# Altium schematic sheet Signal_Generator.SchDoc(Signal_Generator)
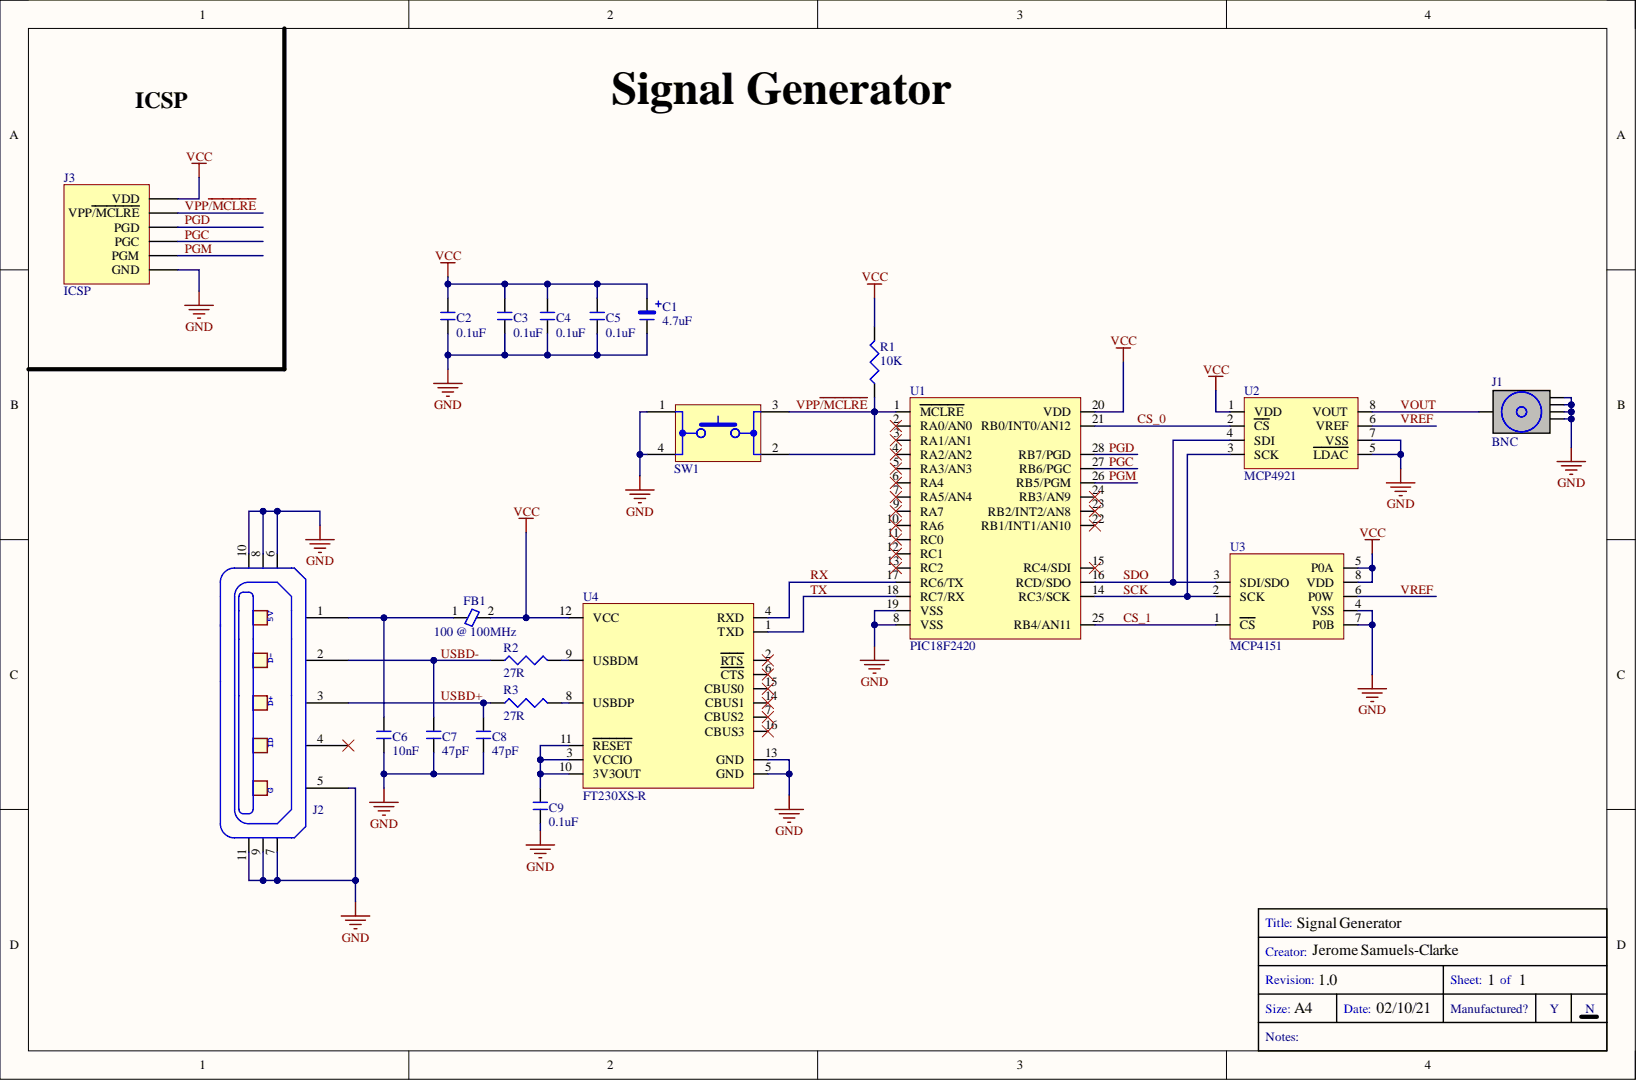
[diagram p0: Signal_Generator.SchDoc]

## Parts
C1  4.7uF  [CAP ALUM 4.7UF]
C2  0.1uF  [C_0603]
C3  0.1uF  [C_0603]
C4  0.1uF  [C_0603]
C5  0.1uF  [C_0603]
C6  10nF  [C_0603]
C7  47pF  [C_0603]
C8  47pF  [C_0603]
C9  0.1uF  [C_0603]
FB1  100 @ 100MHz  [FB_0603]
J1  BNC  [BNC]
J2  10118193-0001LF  [Micro_USB]  (value not drawn)
J3  ICSP  [ICSP]
R1  10K  [R_0603]
R2  27R  [R_0603]
R3  27R  [R_0603]
SW1  SPST  [Right_Angled_Push_Button]  (value not drawn)
U1  PIC18F2420  [PIC18F2420_SOIC]
U2  MCP4921  [MCP4921_MSOP]
U3  MCP4151  [MCP4151_MSOP]
U4  FT230XS-R  [FT230X_SOIC]

## Nets
GND: C1.2 C2.1 C3.1 C4.1 C5.1 C6.1 C7.1 C8.1 C9.1 J1.1 J1.2 J1.3 J1.4 J2.5 J2.6 J2.7 J2.8 J2.9 J2.10 J2.11 J3.3(GND) SW1.1 SW1.4 U1.8(VSS) U1.19(VSS) U2.5(LDAC) U2.7(VSS) U3.4(VSS) U3.7(P0B) U4.5(GND) U4.13(GND)
VCC: C1.1 C2.2 C3.2 C4.2 C5.2 FB1.2 J3.2(VDD) R1.2 U1.20(VDD) U2.1(VDD) U3.5(P0A) U3.8(VDD) U4.12(VCC)
CS_0: U1.21(RB0/INT0/AN12) U2.2(CS)
CS_1: U1.25(RB4/AN11) U3.1(CS)
PGC: J3.5(PGC) U1.27(RB6/PGC)
PGD: J3.4(PGD) U1.28(RB7/PGD)
PGM: J3.6(PGM) U1.26(RB5/PGM)
RX: U1.17(RC6/TX) U4.4(RXD)
SCK: U1.14(RC3/SCK) U2.3(SCK) U3.2(SCK)
SDO: U1.16(RCD/SDO) U2.4(SDI) U3.3(SDI/SDO)
TX: U1.18(RC7/RX) U4.1(TXD)
USBD+: C8.2 J2.3 R3.1
USBD-: C7.2 J2.2 R2.1
VOUT: J1.5 U2.8(VOUT)
VPP~{/MCLRE}: J3.1(VPP/MCLRE) R1.1 SW1.2 SW1.3 U1.1(MCLRE)
VREF: U2.6(VREF) U3.6(P0W)
NetC6_2: C6.2 FB1.1 J2.1
NetC9_2: C9.2 U4.3(VCCIO) U4.10(3V3OUT) U4.11(RESET)
NetR2_2: R2.2 U4.9(USBDM)
NetR3_2: R3.2 U4.8(USBDP)

Not connected: J2 4; U1 2(RA0/AN0) 3(RA1/AN1) 4(RA2/AN2) 5(RA3/AN3) 6(RA4) 7(RA5/AN4) 9(RA7) 10(RA6) 11(RC0) 12(RC1) 13(RC2) 15(RC4/SDI) 22(RB1/INT1/AN10) 23(RB2/INT2/AN8) 24(RB3/AN9); U4 2(RTS) 6(CTS) 7(CBUS2) 14(CBUS1) 15(CBUS0) 16(CBUS3)

## Library data of the parts
C1 = EEE-HA1E4R7AR: Cap Aluminum Lytic 4.7uF 25V 20% (4 X 5.4mm) SMD 22mA 1000h 105C T/R; Manufacturer: Panasonic; Life (Hours): 1000Hour; Polarity: Polar; Capacitance: 4.7uF; Case/Package: Radial; Category: Aluminum Electrolytic Capacitors; Component Kind: Standard; ComponentLink1Description: https://datasheet.ciiva.com/11681/aba0000ce3-11681160.pdf?src-supplier=Avnet; ComponentLink1URL: https://datasheet.ciiva.com/11681/aba0000ce3-11681160.pdf?src-supplier=Avnet; ComponentLink2Description: https://datasheet.ciiva.com/30252/aba0000ce3-30252438.pdf?src-supplier=Onlinecomponents.com; ComponentLink2URL: https://datasheet.ciiva.com/30252/aba0000ce3-30252438.pdf?src-supplier=Onlinecomponents.com; Construction: Cylindrical; Contact Plating: Tin; Diameter: 4mm; Height: 5.4mm; Height - Seated (Max): 0.213inch; Length: 5.5mm; Library Name: Signal_Generator.SchLib; Manufacturer Part Number: EEE-HA1E4R7AR; Max Operating Temperature: 105°C; Min Operating Temperature: -40°C; Mount: Surface Mount; Package Quantity: 1; Packaging: Tape and Reel; Pricing 1: 1=0.35000, 10=0.24400, 50=0.17100, 100=0.14660, 500=0.10996, 1000=0.09286 (USD); Radiation Hardening: No; Ripple Current: 22mA; RoHS: Yes; RoHS Compliant: Yes; Series: HA; Stock 1: 2093; Supplier 1: Digi-Key; Supplier Part Number 1: PCE4667CT-ND; Termination: SMD/SMT; Tolerance: 20%; Voltage Rating: 25V; Voltage Rating (DC): 25V
C2-C5 C9 = CC0603JRX7R7BB104: Cap Ceramic 0.1uF 16V X7R 5% SMD 0603 125C T/R; Manufacturer: Yageo; Capacitance: 100nF; Case Code (Imperial): 0603; Case Code (Metric): 1608; Case/Package: 0603; Category: Ceramic Capacitors; Component Kind: Standard; ComponentLink1Description: https://datasheet.ciiva.com/26862/getdatasheetpartid-403543-26862779.pdf?src-supplier=Verical; ComponentLink1URL: https://datasheet.ciiva.com/26862/getdatasheetpartid-403543-26862779.pdf?src-supplier=Verical; Depth: 0.8mm; Dielectric: X7R; Halogen Free: Halogen Free; Lead Free: Lead Free; Length: 1.6mm; Library Name: Signal_Generator.SchLib; Manufacturer Part Number: CC0603JRX7R7BB104; Max Operating Temperature: 125°C; Min Operating Temperature: -55°C; Mount: Surface Mount; Number of Pins: 2; Packaging: Tape and Reel; Pricing 1: 1=0.10000, 10=0.07700, 50=0.04200, 100=0.03430, 500=0.02448, 1000=0.01923 (USD); Radiation Hardening: No; RoHS: Yes; RoHS Compliant: Yes; Series: CC; Stock 1: 286099; Supplier 1: Digi-Key; Supplier Part Number 1: 311-1776-1-ND; Thickness: 0.035inch; Tolerance: 5%; Voltage Rating: 16V; Voltage Rating (DC): 16V; Width: 0.031inch
C6 = CC0603JRX7R8BB103: Surface Mount Ceramic Multilayer Capacitor 0.01uF 5% X7R 25V 0603 Paper T/R; Manufacturer: Yageo; Dissipation Factor: 2.5%; Insulation Resistance: 10GR; Capacitance: 10nF; Case Code (Imperial): 0603; Case Code (Metric): 1608; Case/Package: 0603; Category: Ceramic Capacitors; Component Kind: Standard; ComponentLink1Description: https://datasheet.ciiva.com/11465/upy-gphc-x7r-6-3v-to-50v-8-11465030.pdf?src-supplier=Avnet; ComponentLink1URL: https://datasheet.ciiva.com/11465/upy-gphc-x7r-6-3v-to-50v-8-11465030.pdf?src-supplier=Avnet; ComponentLink2Description: https://datasheet.ciiva.com/17437/upy-gphc-x7r-6-3v-to-50v-8-17437066.pdf?src-supplier=Avnet+Europe; ComponentLink2URL: https://datasheet.ciiva.com/17437/upy-gphc-x7r-6-3v-to-50v-8-17437066.pdf?src-supplier=Avnet+Europe; Depth: 0.8mm; Dielectric: X7R; Halogen Free: Halogen Free; Height: 0.8mm; Lead Free: Lead Free; Length: 1.6mm; Library Name: Signal_Generator.SchLib; Manufacturer Part Number: CC0603JRX7R8BB103; Max Operating Temperature: 125°C; Min Operating Temperature: -55°C; Mount: Surface Mount; Packaging: Tape and Reel; Pricing 1: 1=0.10000, 10=0.07300, 50=0.03980, 100=0.03250, 500=0.02318, 1000=0.01822 (USD); Radiation Hardening: No; Resistor Type: Ceramic; RoHS: Yes; RoHS Compliant: Yes; Series: CC; Stock 1: 4000; Supplier 1: Digi-Key; Supplier Part Number 1: 311-3995-1-ND; Termination: SMD/SMT; Thickness: 0.035inch; Tolerance: 5%; Voltage Rating: 25V; Voltage Rating (DC): 25V; Weight: 7.1E-05oz; Width: 0.8mm
C7 C8 = CC0603KRNPO9BN470: Cap Ceramic 47pF 50V C0G 10% SMD 0603 125C Paper T/R; Manufacturer: Yageo; Capacitance: 47pF; Case Code (Imperial): 0603; Case Code (Metric): 1608; Case/Package: 0603; Category: Ceramic Capacitors; Component Kind: Standard; ComponentLink1Description: https://datasheet.ciiva.com/13264/upy-gp-np0-16v-to-50v-8-3714-13264856.pdf?src-supplier=Mouser; ComponentLink1URL: https://datasheet.ciiva.com/13264/upy-gp-np0-16v-to-50v-8-3714-13264856.pdf?src-supplier=Mouser; Construction: Flat; Depth: 0.8mm; Dielectric: C0G; Height: 0.8mm; Length: 0.063inch; Library Name: Signal_Generator.SchLib; Manufacturer Part Number: CC0603KRNPO9BN470; Max Operating Temperature: 125°C; Min Operating Temperature: -55°C; Mount: Surface Mount; Packaging: Tape and Reel; Pricing 1: 1=0.10000, 10=0.05800, 50=0.03180, 100=0.02600, 500=0.01856, 1000=0.01458 (USD); Radiation Hardening: No; Resistor Type: Ceramic; RoHS: Yes; RoHS Compliant: Yes; Series: CC; Stock 1: 10; Supplier 1: Digi-Key; Supplier Part Number 1: 311-4037-1-ND; Termination: SMD/SMT; Thickness: 0.035inch; Tolerance: 10%; Voltage Rating: 50V; Voltage Rating (DC): 50V; Weight: 7.1E-05oz; Width: 0.031inch
FB1 = Z0603C101BPWZT: FERRITE BEAD 100 OHM 0603 1LN; Manufacturer: KEMET; DC Resistance (DCR): 35mR; Max Current Rating: 2A; Number of Lines: 1; Case Code (Imperial): 0603; Case Code (Metric): 1608; Case/Package: 0603; Category: Ferrite Beads and Chips; Component Kind: Standard; ComponentLink1Description: http://www.kemet.com/Lists/ProductCatalog/Attachments/57/F3115.pdf?src-supplier=Digi-Key; ComponentLink1URL: http://www.kemet.com/Lists/ProductCatalog/Attachments/57/F3115.pdf?src-supplier=Digi-Key; ComponentLink2Description: https://api.kemet.com/component-edge/download/datasheet/Z0603C101BPWZT.pdf?src-supplier=Digi-Key; ComponentLink2URL: https://api.kemet.com/component-edge/download/datasheet/Z0603C101BPWZT.pdf?src-supplier=Digi-Key; Height: 0.035inch; Length: 0.063inch; Library Name: Signal_Generator.SchLib; Manufacturer Part Number: Z0603C101BPWZT; Max Operating Temperature: 125°C; Min Operating Temperature: -40°C; Mount: Surface Mount; Package Quantity: 1; Packaging: Tape and Reel; Pricing 1: 1=0.23000, 10=0.18500, 25=0.14400, 50=0.11940, 100=0.10280, 250=0.08228, 500=0.07406, 1000=0.06171 (USD); RoHS: Yes; Stock 1: 15061; Supplier 1: Digi-Key; Supplier Part Number 1: 399-9608-1-ND; Width: 0.031inch
J1 = RF1-01A-D-00-75-M: BNC Connector Plug, Male Pin 75Ohm Through Hole, Right Angle Solder; Manufacturer: Adam Equipment; Category: Connectors; Component Kind: Standard; Library Name: Signal_Generator.SchLib; Manufacturer Part Number: RF1-01A-D-00-75-M; Pricing 1: 1=1.79000, 10=1.55700, 25=1.49080, 50=1.45760, 100=1.39120, 250=1.25876, 500=1.15938, 1000=0.99375, 2500=0.92750 (USD); RoHS: No; Stock 1: 155; Supplier 1: Digi-Key; Supplier Part Number 1: 2057-RF1-01A-D-00-75-M-ND
J2 = 10118193-0001LF: USB - micro B USB 2.0 Receptacle Connector 5 Position Surface Mount, Right Angle, Horizontal; Manufacturer: Amphenol FCI; Connector Type: Receptacle,USB; Current Rating: 1.8A; Gender: Female; Insulation Resistance: 1GR; Max Voltage Rating (AC): 100V; Number of Contacts: 5; Number of Ports: 1; Pitch: 0.65mm; Category: Connectors; Colour: Black; Component Kind: Standard; ComponentLink1Description: https://cdn.amphenol-icc.com/media/wysiwyg/files/documentation/datasheet/inputoutput/io_usb_micro.pdf; ComponentLink1URL: https://cdn.amphenol-icc.com/media/wysiwyg/files/documentation/datasheet/inputoutput/io_usb_micro.pdf; Contact Material: Copper Alloy; Contact Plating: Gold; Depth: 5.67mm; Features: Shielded; Flammability Rating: UL94 V-0; Housing Material: Polymer; Length: 7.86mm; Library Name: Signal_Generator.SchLib; Manufacturer Part Number: 10118193-0001LF; Max Operating Temperature: 85°C; Min Operating Temperature: -55°C; Mount: Horizontal,Surface Mount; Number of Terminals: 5; Orientation: Right Angle; Package Quantity: 1200; Packaging: Tape and Reel; Pricing 1: 1=0.42, 10=0.393, 25=0.3536, 50=0.3144, 100=0.3013, 250=0.27512, 500=0.26202 (USD); Radiation Hardening: No; Stock 1: 266455; Supplier 1: Digi-Key; Supplier Part Number 1: 609-4616-1-ND; Termination: Solder; Voltage Rating: 100V
R1 = RC0603FR-0710KL: Res General Purpose Thick Film 0603 10K Ohm 1% 1/10W ±100ppm/°C Molded SMD Paper T/R; Manufacturer: Yageo; Max Power Dissipation: 100mW; Resistance: 10kR; Voltage Rating: 75V; Voltage Rating (DC): 50V; Case Code (Imperial): 0603; Case Code (Metric): 1608; Case/Package: 0603; Category: Chip SMD Resistors; Component Kind: Standard; ComponentLink1Description: https://datasheet.ciiva.com/7660/38590-7660789.pdf?src-supplier=Element14; ComponentLink1URL: https://datasheet.ciiva.com/7660/38590-7660789.pdf?src-supplier=Element14; ComponentLink2Description: https://datasheet.ciiva.com/7983/443971-7983596.pdf?src-supplier=Element14; ComponentLink2URL: https://datasheet.ciiva.com/7983/443971-7983596.pdf?src-supplier=Element14; ComponentLink3Description: https://datasheet.ciiva.com/22747/443971-22747519.pdf?src-supplier=Element14; ComponentLink3URL: https://datasheet.ciiva.com/22747/443971-22747519.pdf?src-supplier=Element14; ComponentLink4Description: https://datasheet.ciiva.com/46440/1868787-46440891.pdf?src-supplier=Element14; ComponentLink4URL: https://datasheet.ciiva.com/46440/1868787-46440891.pdf?src-supplier=Element14; ComponentLink5Description: https://datasheet.ciiva.com/7746/1738695-7746239.pdf?src-supplier=Element14; ComponentLink5URL: https://datasheet.ciiva.com/7746/1738695-7746239.pdf?src-supplier=Element14; ComponentLink6Description: https://datasheet.ciiva.com/15189/getdatasheetpartid-409289-15189591.pdf?src-supplier=Verical; ComponentLink6URL: https://datasheet.ciiva.com/15189/getdatasheetpartid-409289-15189591.pdf?src-supplier=Verical; Construction: Rectangular; Depth: 0.8mm; Features: Moisture Resistant; Height: 0.45mm; Lead Free: Lead Free; Lead Pitch: 0.3mm; Length: 1.6mm; Library Name: Signal_Generator.SchLib; Manufacturer Part Number: RC0603FR-0710KL; Max Operating Temperature: 155°C; Military Standard: Not; Min Operating Temperature: -55°C; Number of Pins: 2; Number of Terminations: 2; Packaging: Tape and Reel; Power Rating: 100mW; Pricing 1: 1=0.10000, 10=0.02400, 100=0.00970, 1000=0.00436, 2500=0.00378 (USD); REACH SVHC: Unknown; Resistance Tolerance: 1%; Resistor Type: Thick Film; RoHS: Yes; RoHS Compliant: Yes; Series: RC; Size Code: 0603; Stock 1: 10216370; Supplier 1: Digi-Key; Supplier Part Number 1: 311-10.0KHRCT-ND; Temperature Coefficient: 100ppm/°C; Termination: SMD/SMT; Tolerance: 1%; Weight: 7.1E-05oz; Width: 0.8mm
R2 R3 = RC0603JR-0727RL: YAGEO - RC0603JR-0727RL - SMD Chip Resistor, 0603 [1608 Metric], 27 ohm, RC Series, 75 V, Thick Film, 100 mW; Max Power Dissipation: 100mW; Resistance Tolerance: 5%; Temperature Coefficient: 100ppm/°C; Voltage Rating: 50V; Case Code (Imperial): 0603; Case Code (Metric): 1608; Case/Package: 0603; Category: Chip SMD Resistors; Component Kind: Standard; ComponentLink1Description: https://datasheet.ciiva.com/7746/443971-7746479.pdf?src-supplier=Element14; ComponentLink1URL: https://datasheet.ciiva.com/7746/443971-7746479.pdf?src-supplier=Element14; Construction: Rectangular; Depth: 0.8mm; Features: Moisture Resistant; Lead Free: Lead Free; Length: 1.6mm; Library Name: Signal_Generator.SchLib; Manufacturer: Yageo; Manufacturer Part Number: RC0603JR-0727RL; Max Operating Temperature: 155°C; Military Standard: Not; Min Operating Temperature: -55°C; Number of Pins: 2; Number of Terminations: 2; Packaging: Tape and Reel; Power Rating: 100mW; Pricing 1: 1=0.10000, 10=0.02000, 100=0.00800, 1000=0.00360, 2500=0.00312 (USD); REACH SVHC: No SVHC; Resistance: 27R; Resistor Type: Thick Film; RoHS: Yes; RoHS Compliant: Yes; Series: RC; Size Code: 0603; Stock 1: 1539058; Supplier 1: Digi-Key; Supplier Part Number 1: 311-27GRCT-ND; Termination: SMD/SMT; Tolerance: 5%; Voltage Rating (DC): 50V; Width: 0.8mm
SW1 = SPST: IP40 Black Button Tactile Switch, SPST-NO; Manufacturer: Schurter; Manufacturer Part Number: 1301.9501; Actuator Type: Standard; Current Rating: 50mA; Illumination Type: Non-Illuminated,Illuminated; Max Voltage Rating (DC): 12V; Mechanical Life: 100000Cycles; Operating Force: 1.6N; Switch Function: Off-Mom; Switch Travel: 0.25mm; Category: Tactile Switches; Component Kind: Standard; ComponentLink1Description: https://datasheet.ciiva.com/15226/getdatasheetpartid-726410-15226643.pdf?src-supplier=Verical; ComponentLink1URL: https://datasheet.ciiva.com/15226/getdatasheetpartid-726410-15226643.pdf?src-supplier=Verical; ComponentLink2Description: https://datasheet.ciiva.com/16696/typ-lsg-16696700.pdf?src-supplier=Avnet+Europe; ComponentLink2URL: https://datasheet.ciiva.com/16696/typ-lsg-16696700.pdf?src-supplier=Avnet+Europe; ComponentLink3Description: https://datasheet.ciiva.com/1224/1833020-1224148.pdf?src-supplier=Element14; ComponentLink3URL: https://datasheet.ciiva.com/1224/1833020-1224148.pdf?src-supplier=Element14; ComponentLink4Description: https://datasheet.ciiva.com/8166/typ-lsg-8166698.pdf?src-supplier=Avnet; ComponentLink4URL: https://datasheet.ciiva.com/8166/typ-lsg-8166698.pdf?src-supplier=Avnet; ComponentLink5Description: https://datasheet.ciiva.com/2903/typ-lph-2903030.pdf?src-supplier=Digi-Key; ComponentLink5URL: https://datasheet.ciiva.com/2903/typ-lph-2903030.pdf?src-supplier=Digi-Key; ComponentLink6Description: https://datasheet.ciiva.com/49023/70435860-49023948.pdf?src-supplier=Allied+Electronics; ComponentLink6URL: https://datasheet.ciiva.com/49023/70435860-49023948.pdf?src-supplier=Allied+Electronics; Contact Material: Copper,Silver; Lead Free: Lead Free; Length: 7.5mm; Library Name: Signal_Generator.SchLib; Max Current Rating: 50mA; Max Operating Temperature: 70°C; Min Operating Temperature: -20°C; Mount: Through Hole; Orientation: Right Angle; Package Quantity: 2000; Packaging: Box; Pricing 1: 1=0.30000, 50=0.29100, 100=0.27120 (USD); Radiation Hardening: No; Ratings: IP40; REACH SVHC: No SVHC; RoHS: Yes; RoHS Compliant: Yes; Series: LPV; Stock 1: 13993; Supplier 1: Digi-Key; Supplier Part Number 1: 486-3471-ND; Termination: PC Pins; Throw Configuration: SPST; Voltage Rating: 12V; Voltage Rating (DC): 12V; Width: 6.35mm
U1 = PIC18F2420-I/SO: MICROCHIP - PIC18F2420-I/SO - MCU, 8BIT, PIC18, 40MHZ, SOIC-28; Access Time: 40us; Address Bus Width: 8b; Core Architecture: PIC; Density: 16KB; Interface: I2C,SPI,USART,UART; Max Power Dissipation: 1W; Max Supply Voltage: 5.5V; Memory Type: FLASH; Min Supply Voltage: 4.2V; Nominal Supply Current: 1.1mA; Number of A/D Converters: 1; Number of ADC Channels: 10; Number of Bits: 8; Number of I/Os: 25; Number of PWM Channels: 2; Number of Timers/Counters: 4; Oscillator Type: Internal; Peripherals: PWM,I2C,Brown-out Detect/Reset,POR,WDT,HLVD; RAM Size: 768B; Watchdog Timer: Yes; Case/Package: SOIC; Category: Microcontrollers; Component Kind: Standard; ComponentLink1Description: https://datasheet.ciiva.com/4839/39631a-55776-4839040.pdf?src-supplier=Mouser; ComponentLink1URL: https://datasheet.ciiva.com/4839/39631a-55776-4839040.pdf?src-supplier=Mouser; ComponentLink2Description: https://datasheet.ciiva.com/29331/165240-da-01-en-pic18f2420-i-so-29331710.pdf?src-supplier=Conrad; ComponentLink2URL: https://datasheet.ciiva.com/29331/165240-da-01-en-pic18f2420-i-so-29331710.pdf?src-supplier=Conrad; ComponentLink3Description: https://datasheet.ciiva.com/14561/70045660-14561701.pdf?src-supplier=Allied+Electronics; ComponentLink3URL: https://datasheet.ciiva.com/14561/70045660-14561701.pdf?src-supplier=Allied+Electronics; ComponentLink4Description: https://datasheet.ciiva.com/14131/pic18f2420-2520-4420-4520-eng-tds-14131910.pdf?src-supplier=Distrelec; ComponentLink4URL: https://datasheet.ciiva.com/14131/pic18f2420-2520-4420-4520-eng-tds-14131910.pdf?src-supplier=Distrelec; ComponentLink5Description: https://datasheet.ciiva.com/9237/39631e-9237591.pdf?src-supplier=Avnet; ComponentLink5URL: https://datasheet.ciiva.com/9237/39631e-9237591.pdf?src-supplier=Avnet; ComponentLink6Description: https://datasheet.ciiva.com/1492/39631e-1492938.pdf?src-supplier=Digi-Key; ComponentLink6URL: https://datasheet.ciiva.com/1492/39631e-1492938.pdf?src-supplier=Digi-Key; ComponentLink7Description: https://datasheet.ciiva.com/6132/39631e-6132573.pdf?src-supplier=Farnell; ComponentLink7URL: https://datasheet.ciiva.com/6132/39631e-6132573.pdf?src-supplier=Farnell; Data Bus Width: 8b; Frequency: 40MHz; Height: 2.35mm; Lead Free: Lead Free; Length: 17.9mm; Library Name: Signal_Generator.SchLib; Manufacturer: Microchip; Manufacturer Part Number: PIC18F2420-I/SO; Max Frequency: 40MHz; Max Operating Temperature: 85°C; Memory Size: 16KB; Min Operating Temperature: -40°C; Mount: Surface Mount; Number of I2C Channels: 1; Number of Pins: 28; Number of Programmable I/O: 25; Number of SPI Channels: 1; Number of UART Channels: 1; Package Quantity: 27; Packaging: Rail/Tube; Pricing 1: 1=5.40000, 10=5.40000, 50=5.40000, 100=5.40000, 1000=5.40000, 10000=5.40000 (USD); Radiation Hardening: No; REACH SVHC: No SVHC; RoHS: Yes; RoHS Compliant: Yes; Stock 1: 29; Supplier 1: Mouser; Supplier Part Number 1: 579-PIC18F2420-I/SO; Supply Current: 1.1mA; Width: 7.5mm
U2 = MCP4921T-E/MS: MCP4921 Series 1 Ch 12-Bit Voltage Output Digital-to-Analog Converter-MSOP-8; Manufacturer: Microchip; Input Offset Voltage (Vos): 820uV; Integral Nonlinearity (INL): 12LSB; Interface: SPI,Serial; Max Supply Voltage: 5.5V; Min Supply Voltage: 2.7V; Nominal Supply Current: 175uA; Number of Bits: 12; Number of Channels: 1; Operating Supply Voltage: 5V; Resolution: 12b; Settling Time: 4.5us; Supply Type: Single; Case/Package: MSOP; Category: Digital to Analog Converters (DACs); Component Kind: Standard; ComponentLink1Description: https://datasheet.ciiva.com/41459/001083287-da-01-en-ic-dac-12-w-spi-mcp4921t-e-ms-msop-8-mcp-41459046.pdf?src-supplier=Conrad; ComponentLink1URL: https://datasheet.ciiva.com/41459/001083287-da-01-en-ic-dac-12-w-spi-mcp4921t-e-ms-msop-8-mcp-41459046.pdf?src-supplier=Conrad; ComponentLink2Description: https://datasheet.ciiva.com/6666/22248a-6666553.pdf?src-supplier=Digi-Key; ComponentLink2URL: https://datasheet.ciiva.com/6666/22248a-6666553.pdf?src-supplier=Digi-Key; ComponentLink3Description: https://datasheet.ciiva.com/6666/22248a-6666558.pdf?src-supplier=Digi-Key; ComponentLink3URL: https://datasheet.ciiva.com/6666/22248a-6666558.pdf?src-supplier=Digi-Key; ComponentLink4Description: https://datasheet.ciiva.com/3041/22248a-3041510.pdf?src-supplier=Avnet; ComponentLink4URL: https://datasheet.ciiva.com/3041/22248a-3041510.pdf?src-supplier=Avnet; ComponentLink5Description: https://datasheet.ciiva.com/8035/22248a-8035052.pdf?src-supplier=Element14; ComponentLink5URL: https://datasheet.ciiva.com/8035/22248a-8035052.pdf?src-supplier=Element14; ComponentLink6Description: https://datasheet.ciiva.com/16625/22248a-16625692.pdf?src-supplier=Avnet+Europe; ComponentLink6URL: https://datasheet.ciiva.com/16625/22248a-16625692.pdf?src-supplier=Avnet+Europe; ComponentLink7Description: https://datasheet.ciiva.com/16203/filehandler-aspxddocname-en547911-16203277.pdf?src-supplier=Future+Electronics; ComponentLink7URL: https://datasheet.ciiva.com/16203/filehandler-aspxddocname-en547911-16203277.pdf?src-supplier=Future+Electronics; Lead Free: Lead Free; Library Name: Signal_Generator.SchLib; Manufacturer Part Number: MCP4921T-E/MS; Max Operating Temperature: 125°C; Min Operating Temperature: -40°C; Mount: Surface Mount; Number of Converters: 1; Number of DAC Channels: 1; Number of Pins: 8; Output Type: Voltage; Package Quantity: 1; Packaging: Tape and Reel; Pricing 1: 2500=1.80200 (USD); Radiation Hardening: No; REACH SVHC: No SVHC; RoHS: Yes; Stock 1: 2500; Supplier 1: Digi-Key; Supplier Part Number 1: MCP4921T-E/MSTR-ND
U3 = MCP4151T-103E/SN: MICROCHIP - MCP4151T-103E/SN - IC,Digital Potentiometer,CMOS,SOP,8PIN,PLASTIC; Interface: SPI,Serial; Max Supply Voltage: 5.5V; Memory Type: Volatile; Min Supply Voltage: 1.8V; Nominal Supply Current: 1mA; Number of Circuits: 1; Number of Positions: 256; Number of Taps: 257; Operating Supply Voltage: 5V; Resistance: 10kR; Temperature Coefficient: 150ppm/°C; Tolerance: 20%; Case/Package: SOIC N; Category: Digital Potentiometers; Component Kind: Standard; ComponentLink1Description: https://datasheet.ciiva.com/1336/22060b-1336946.pdf?src-supplier=Digi-Key; ComponentLink1URL: https://datasheet.ciiva.com/1336/22060b-1336946.pdf?src-supplier=Digi-Key; ComponentLink2Description: https://datasheet.ciiva.com/8188/22060b-8188822.pdf?src-supplier=Avnet; ComponentLink2URL: https://datasheet.ciiva.com/8188/22060b-8188822.pdf?src-supplier=Avnet; Library Name: Signal_Generator.SchLib; Manufacturer: Microchip; Manufacturer Part Number: MCP4151T-103E/SN; Max Operating Temperature: 125°C; Min Operating Temperature: -40°C; Mount: Surface Mount; Number of Elements: 1; Number of Pins: 8; Package Quantity: 3300; Packaging: Tape and Reel; Pricing 1: 1=1.10000, 25=0.91160, 100=0.84000 (USD); Radiation Hardening: No; RoHS: Yes; Stock 1: 1578; Supplier 1: Digi-Key; Supplier Part Number 1: MCP4151T-103E/SNCT-ND
U4 = FT230XS-R: USB-to-UART 1-CH 512byte FIFO 5V 16-Pin SSOP T/R; Manufacturer: FTDI; Data Rate: 12Mbps; Interface: UART,USB; Max Supply Voltage: 5.5V; Min Supply Voltage: 2.97V; Number of Channels: 1; Operating Supply Current: 8mA; Case/Package: SSOP; Category: Interface ICs; Component Kind: Standard; ComponentLink1Description: https://datasheet.ciiva.com/1787/ds-ft230x-1787315.pdf?src-supplier=Digi-Key; ComponentLink1URL: https://datasheet.ciiva.com/1787/ds-ft230x-1787315.pdf?src-supplier=Digi-Key; ComponentLink2Description: https://datasheet.ciiva.com/4787/ds-ft230x-5395-4787571.pdf?src-supplier=Mouser; ComponentLink2URL: https://datasheet.ciiva.com/4787/ds-ft230x-5395-4787571.pdf?src-supplier=Mouser; ComponentLink3Description: https://datasheet.ciiva.com/30478/0900766b811020cb-30478167.pdf?src-supplier=RSComponents; ComponentLink3URL: https://datasheet.ciiva.com/30478/0900766b811020cb-30478167.pdf?src-supplier=RSComponents; ComponentLink4Description: https://datasheet.ciiva.com/1787/ft-x-series-1787316.pdf?src-supplier=Digi-Key; ComponentLink4URL: https://datasheet.ciiva.com/1787/ft-x-series-1787316.pdf?src-supplier=Digi-Key; ComponentLink5Description: https://datasheet.ciiva.com/1787/ft-x-series-1787317.pdf?src-supplier=Digi-Key; ComponentLink5URL: https://datasheet.ciiva.com/1787/ft-x-series-1787317.pdf?src-supplier=Digi-Key; Height: 0.059inch; Length: 5mm; Library Name: Signal_Generator.SchLib; Manufacturer Part Number: FT230XS-R; Max Operating Temperature: 85°C; Min Operating Temperature: -40°C; Mount: Surface Mount; Number of Pins: 16; Operating Supply Voltage: 5V; Package Quantity: 3000; Packaging: Tape and Reel; Pricing 1: 1=2.14000, 25=2.07000, 100=2.00000, 250=1.81000, 500=1.64000, 1000=1.61250 (USD); RoHS: Yes; RoHS Compliant: Yes; Series: USBmadeEZ-UART, FT-X, X-Chip; Supplier 1: Digi-Key; Supplier Part Number 1: 768-1135-6-ND; Weight: 0.004586oz; Width: 0.157inch
J3 = ICSP: Component Kind: Standard; Library Name: Signal_Generator.SchLib
